# Altium schematic sheet 03_UART.SchDoc
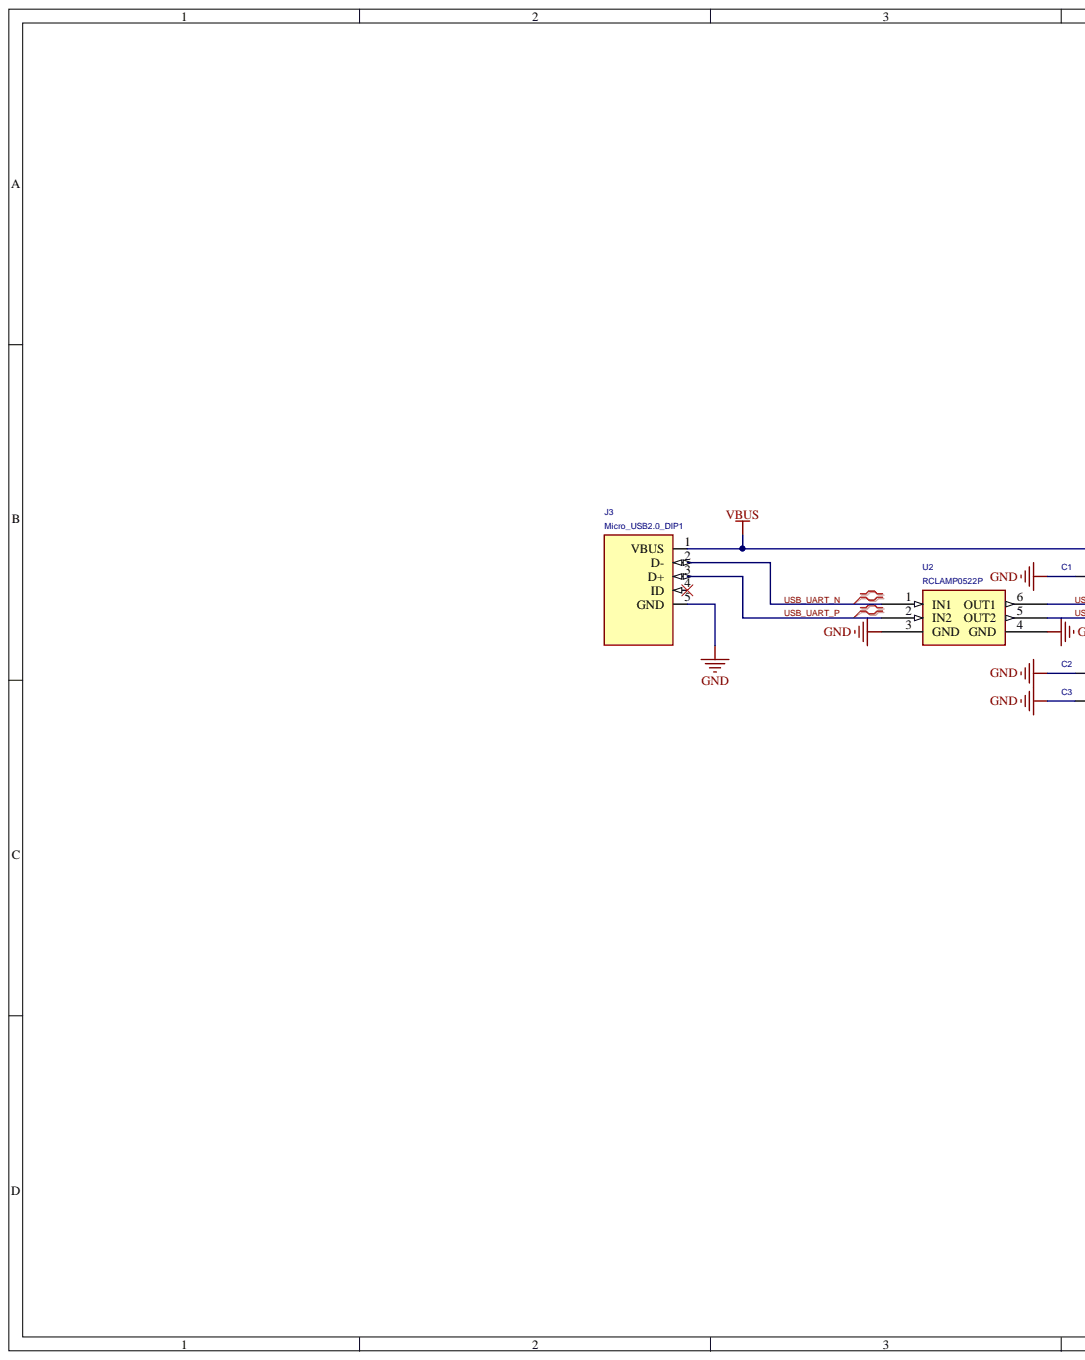
[diagram p0: 03_UART.SchDoc, left]
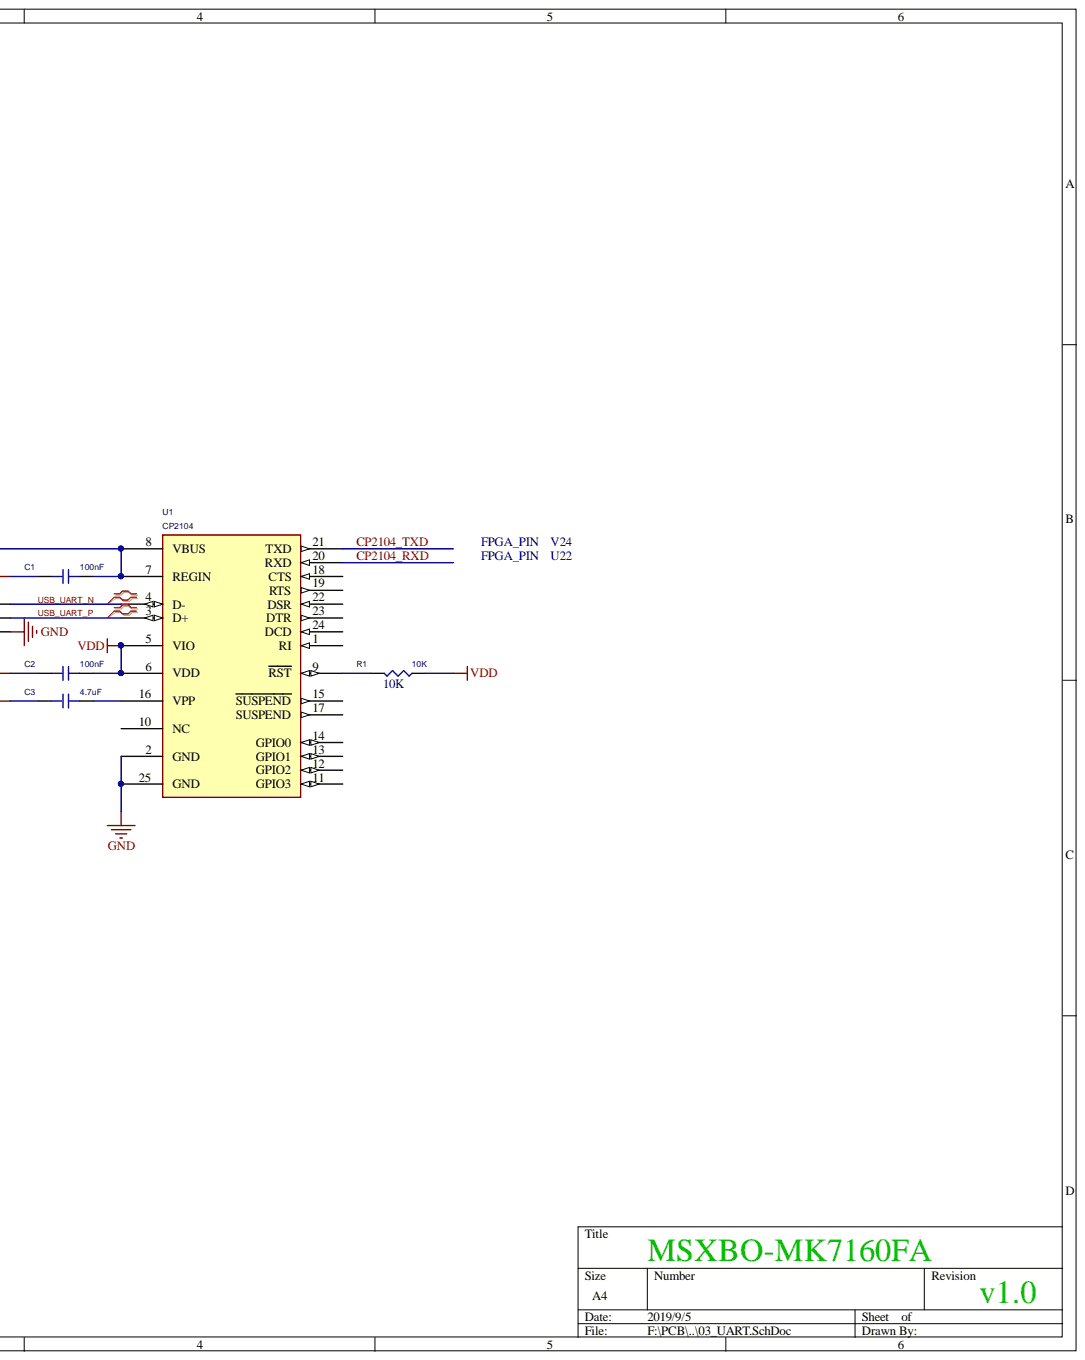
[diagram p1: 03_UART.SchDoc, right]

## Parts
C1  100nF  [CAP0402]
C2  100nF  [CAP0402]
C3  4.7uF  [C0603]
J3  Micro_USB2.0_DIP1  [Micro_USB2_0_SMD]
R1  10K  [R0603]
U1  CP2104  [QFN24_50P4X4X1]
U2  RCLAMP0522P  [SLP1610P4_6]

## Nets
GND: C1.1 C2.1 C3.1 J3.5(GND) U1.2(GND) U1.25(GND) U2.3(GND) U2.4(GND)
VBUS: C1.2 J3.1(VBUS) U1.7(REGIN) U1.8(VBUS)
VDD: C2.2 R1.2 U1.5(VIO) U1.6(VDD)
CP2104_RXD: U1.20(RXD)
CP2104_TXD: U1.21(TXD)
USB_UART_N: J3.2(D-) U1.4(D-) U2.1(IN1) U2.6(OUT1)
USB_UART_P: J3.3(D+) U1.3(D+) U2.2(IN2) U2.5(OUT2)
NetC3_2: C3.2 U1.16(VPP)
NetR1_1: R1.1 U1.9(RST)
FPGA_PIN:
U22:
V24:

Not connected: J3 4(ID); U1 1(RI) 10(NC) 11(GPIO3) 12(GPIO2) 13(GPIO1) 14(GPIO0) 15(SUSPEND) 17(SUSPEND) 18(CTS) 19(RTS) 22(DSR) 23(DTR) 24(DCD)

## Library data of the parts
C1-C3 = CAP1: CURRENT: CIMAX; DIST: FLAT; KNEE: CBMAX; MAX_TEMP: CTMAX; PSpiceTemplate: C^@REFDES %1 %2 ?TOLERANCE|C^@REFDES| @VALUE ?IC/IC=@IC/ TC=@TC1,@TC2 ?TOLERANCE|\n.model C^@REFDES CAP C=1 DEV=@TOLERANCE% TC1=@TC1 TC2=@TC2 VC1=@VC1 VC2=@VC2|; SLOPE: CSMAX; TC1: 0; VOLTAGE: CMAX; Component Kind: Standard; Simulation: CAP1; TC2: 0; VC1: 0; VC2: 0
  C1 C2: Value: 100nF
  C3: Value: 4.7uF
J3 = Micro_USB2_0.0_DIP1: Component Kind: Standard
R1 = RES1: DIST: FLAT; MAX_TEMP: RTMAX; POWER: RMAX; PSpiceTemplate: R^@REFDES %1 %2 ?TOLERANCE|R^@REFDES| @VALUE TC=@TC1,@TC2 ?TOLERANCE|\n.model R^@REFDES RES R=1 DEV=@TOLERANCE% TC1=@TC1 TC2=@TC2|; SLOPE: RSMAX; TC1: 0; VOLTAGE: RVMAX; Component Kind: Standard; Simulation: RES1; TC2: 0; Value: 10K
U1 = CP2104: Component Kind: Standard
U2 = RCLAMP0522P: Component Kind: Standard
